# Altium schematic sheet MCU_and_conncectors.SchDoc(MCU_and_conncectors)
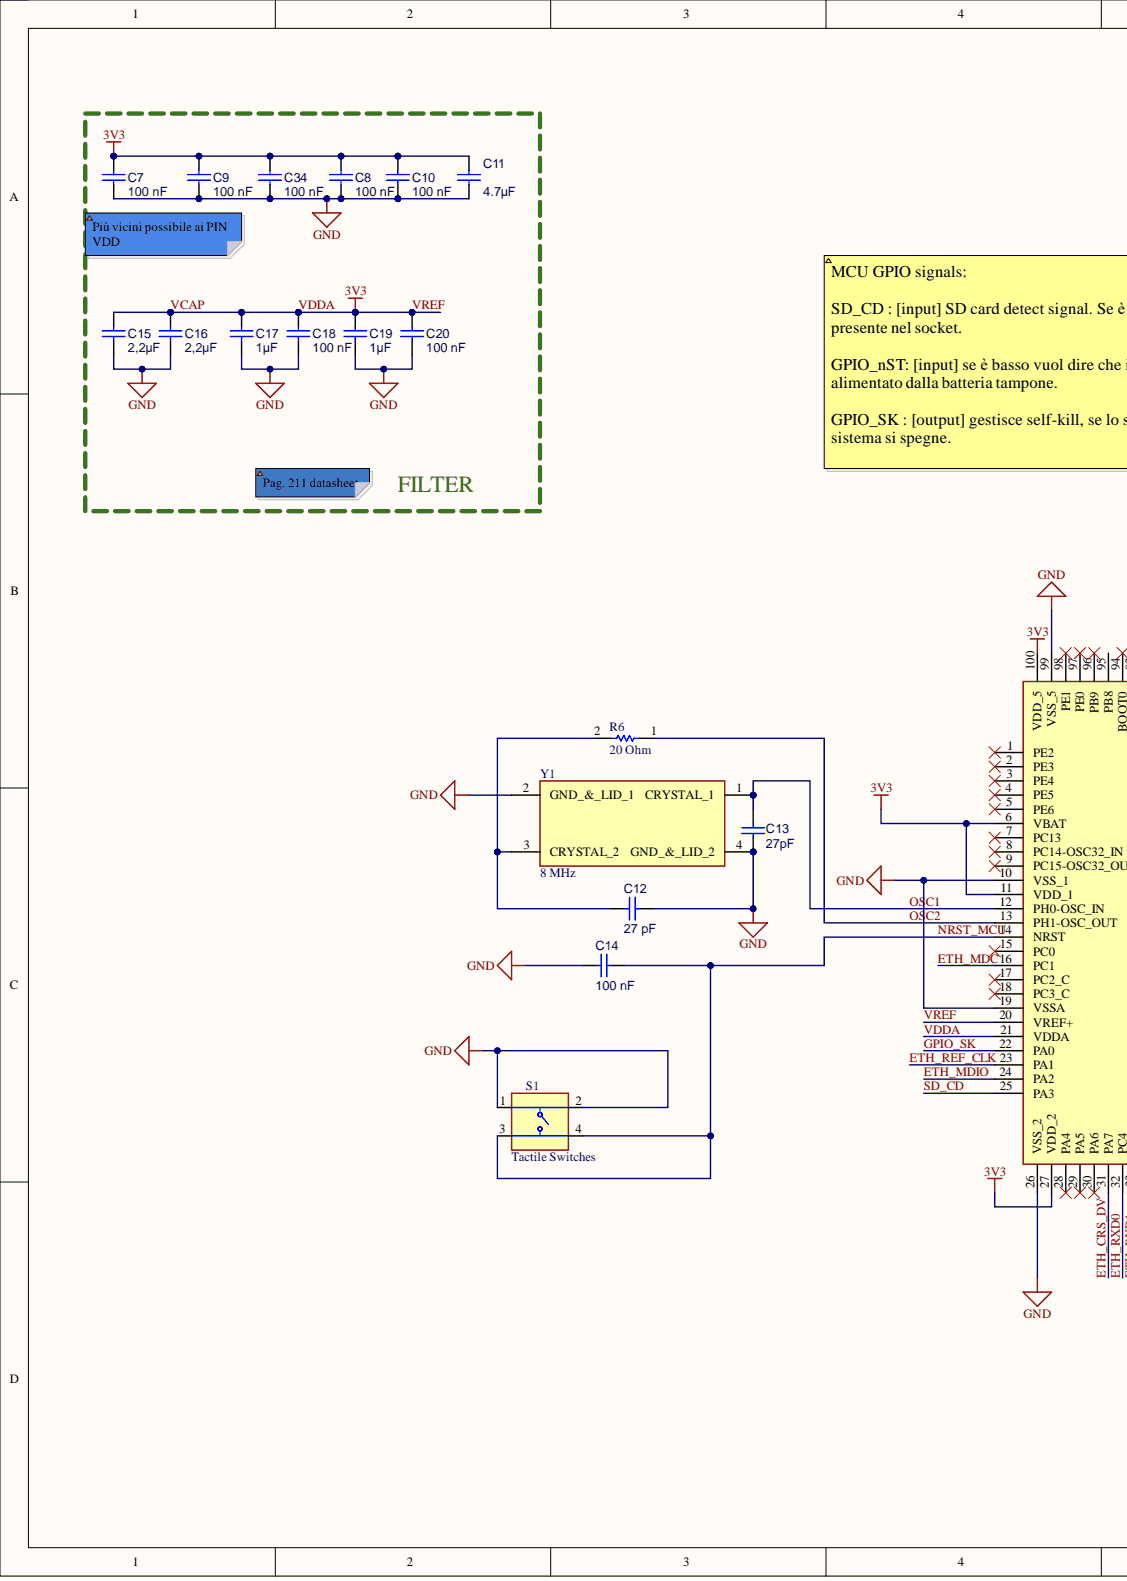
[diagram p0: MCU_and_conncectors.SchDoc, left]
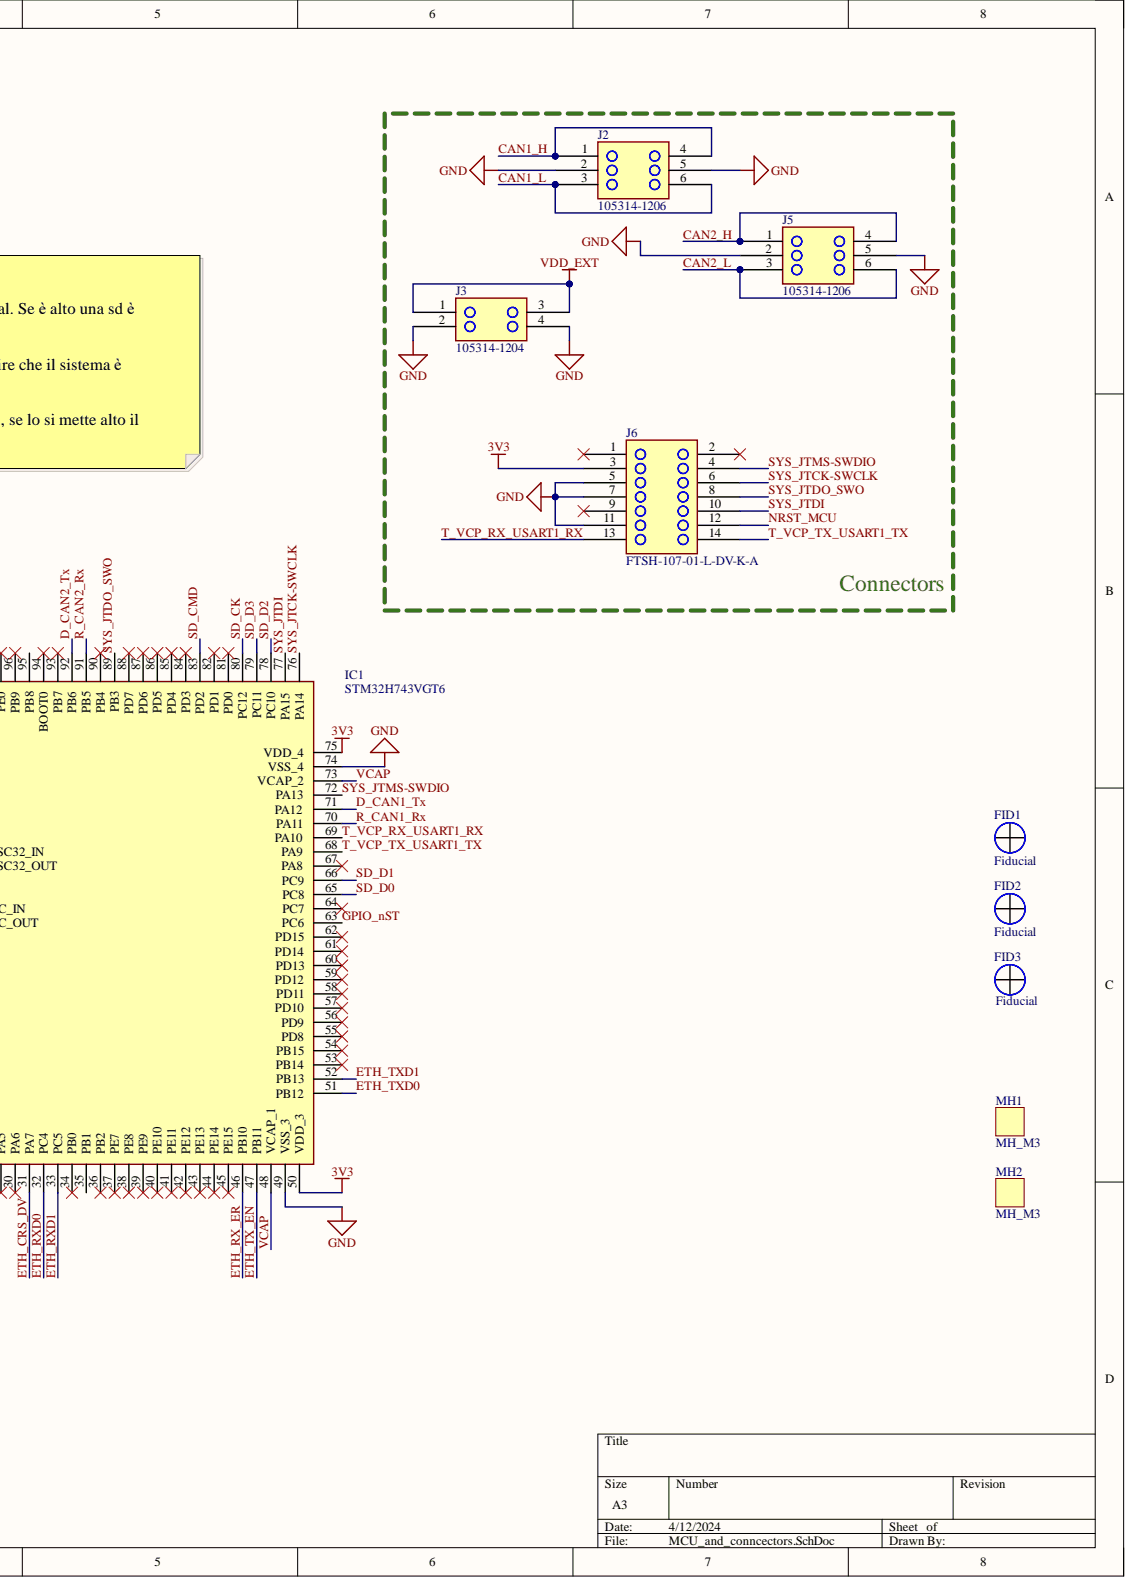
[diagram p1: MCU_and_conncectors.SchDoc, right]

## Parts
C7  100 nF
C8  100 nF
C9  100 nF
C10  100 nF
C11  4.7µF
C12  27 pF
C13  27pF
C14  100 nF
C15  2,2µF
C16  2,2µF
C17  1µF
C18  100 nF
C19  1µF
C20  100 nF
C34  100 nF
FID1  Fiducial
FID2  Fiducial
FID3  Fiducial
IC1  STM32H743VGT6
J2  105314-1206
J3  105314-1204
J5  105314-1206
J6  FTSH-107-01-L-DV-K-A
MH1  MH_M3
MH2  MH_M3
R6  20 Ohm
S1  Tactile Switches
Y1  8 MHz

## Nets
GND: C7.1 C8.1 C9.1 C10.1 C11.1 C12.1 C13.2 C14.2 C15.1 C16.1 C17.1 C18.1 C19.1 C20.1 C34.1 IC1.10(VSS_1) IC1.19(VSSA) IC1.26(VSS_2) IC1.49(VSS_3) IC1.74(VSS_4) IC1.99(VSS_5) J2.2 J2.5 J3.2 J3.4 J5.2 J5.5 J6.5 J6.7 J6.11 S1.1 S1.2 Y1.2(GND_&_LID_1) Y1.4(GND_&_LID_2)
VDD_EXT: J3.1 J3.3
CAN1_H: J2.1 J2.4
CAN1_L: J2.3 J2.6
CAN2_H: J5.1 J5.4
CAN2_L: J5.3 J5.6
D_CAN1_Tx: IC1.71(PA12)
D_CAN2_Tx: IC1.92(PB6)
ETH_CRS_DV: IC1.31(PA7)
ETH_MDC: IC1.16(PC1)
ETH_MDIO: IC1.24(PA2)
ETH_REF_CLK: IC1.23(PA1)
ETH_RX_ER: IC1.46(PB10)
ETH_RXD0: IC1.32(PC4)
ETH_RXD1: IC1.33(PC5)
ETH_TX_EN: IC1.47(PB11)
ETH_TXD0: IC1.51(PB12)
ETH_TXD1: IC1.52(PB13)
GPIO_nST: IC1.63(PC6)
GPIO_SK: IC1.22(PA0)
NRST_MCU: C14.1 IC1.14(NRST) J6.12 S1.3 S1.4
OSC1: C13.1 IC1.12(PH0-OSC_IN) Y1.1(CRYSTAL_1)
OSC2: IC1.13(PH1-OSC_OUT) R6.1
R_CAN1_Rx: IC1.70(PA11)
R_CAN2_Rx: IC1.91(PB5)
SD_CD: IC1.25(PA3)
SD_CK: IC1.80(PC12)
SD_CMD: IC1.83(PD2)
SD_D0: IC1.65(PC8)
SD_D1: IC1.66(PC9)
SD_D2: IC1.78(PC10)
SD_D3: IC1.79(PC11)
SYS_JTCK-SWCLK: IC1.76(PA14) J6.6
SYS_JTDI: IC1.77(PA15) J6.10
SYS_JTDO_SWO: IC1.89(PB3) J6.8
SYS_JTMS-SWDIO: IC1.72(PA13) J6.4
T_VCP_RX_USART1_RX: IC1.69(PA10) J6.13
T_VCP_TX_USART1_TX: IC1.68(PA9) J6.14
VCAP: C7.2 C8.2 C9.2 C10.2 C11.2 C15.2 C16.2 C17.2 C18.2 C19.2 C20.2 C34.2 IC1.6(VBAT) IC1.11(VDD_1) IC1.20(VREF+) IC1.21(VDDA) IC1.27(VDD_2) IC1.48(VCAP_1) IC1.50(VDD_3) IC1.73(VCAP_2) IC1.75(VDD_4) IC1.100(VDD_5) J6.3
NetC12_2: C12.2 R6.2 Y1.3(CRYSTAL_2)

Not connected: IC1 1(PE2) 2(PE3) 3(PE4) 4(PE5) 5(PE6) 7(PC13) 8(PC14-OSC32_IN) 9(PC15-OSC32_OUT) 15(PC0) 17(PC2_C) 18(PC3_C) 28(PA4) 29(PA5) 30(PA6) 34(PB0) 35(PB1) 36(PB2) 37(PE7) 38(PE8) 39(PE9) 40(PE10) 41(PE11) 42(PE12) 43(PE13) 44(PE14) 45(PE15) 53(PB14) 54(PB15) 55(PD8) 56(PD9) 57(PD10) 58(PD11) 59(PD12) 60(PD13) 61(PD14) 62(PD15) 64(PC7) 67(PA8) 81(PD0) 82(PD1) 84(PD3) 85(PD4) 86(PD5) 87(PD6) 88(PD7) 90(PB4) 93(PB7) 94(BOOT0) 95(PB8) 96(PB9) 97(PE0) 98(PE1); J6 1 2 9

## Library data of the parts
C7-C10 C14 C18 C20 C34 = CMP-001-00085-5: VJ0805Y104MXATW1BC; Tech: SMD; Component Kind: Standard; ComponentLink1Description: Website; Package: 0805; Library Name: EMEA- Squadra Corse PoliTO-  Politecnico di Torino
C11 = CMP-001-00085-5: VJ0805G475KXQTW1BC; Tech: SMD; Component Kind: Standard; ComponentLink1Description: Website; Package: 0805; Library Name: EMEA- Squadra Corse PoliTO-  Politecnico di Torino
C12 C13 = CMP-001-00085-5: VJ0805A270FXXPW1BC; Tech: SMD; Component Kind: Standard; ComponentLink1Description: Website; Package: 0805; Library Name: EMEA- Squadra Corse PoliTO-  Politecnico di Torino
C15 C16 = CMP-001-00085-5: VJ0805Y225KXJTW1BC; Tech: SMD; Component Kind: Standard; ComponentLink1Description: Website; Package: 0805; Library Name: EMEA- Squadra Corse PoliTO-  Politecnico di Torino
C17 C19 = CMP-001-00085-5: VJ0805Y105KXXTW1BC; Tech: SMD; Component Kind: Standard; ComponentLink1Description: Website; Package: 0805; Library Name: EMEA- Squadra Corse PoliTO-  Politecnico di Torino
FID1-FID3 = CMP-00028-00007-10: Component Kind: Standard; Library Name: EMEA- Squadra Corse PoliTO-  Politecnico di Torino
IC1 = CMP-030-000044-1: Microcontrollori ARM - MCU High-performance & DSP DP-FPU, Arm Cortex-M7 MCU 1MBytes of Flash 1MB RAM, 480 M; Manufacturer_Name: STMicroelectronics; Arrow Part Number: STM32H743VGT6; Arrow Price/Stock: https://www.arrow.com/en/products/stm32h743vgt6/stmicroelectronics?region=nac; Component Kind: Standard; Datasheet Link: https://componentsearchengine.com/Datasheets/1/STM32H743VGT6.pdf; Design Item ID: STM32H743VGT6; Height: 1.6mm; Manufacturer_Part_Number: STM32H743VGT6; Mouser Part Number: 511-STM32H743VGT6; Mouser Price/Stock: https://www.mouser.co.uk/ProductDetail/STMicroelectronics/STM32H743VGT6?qs=%252B6g0mu59x7JjCCaXtUod8g%3D%3D; Source: SamacSys.SchLib; Library Name: EMEA- Squadra Corse PoliTO-  Politecnico di Torino
J2 J5 = CMP-000003-4: NanoFit 6 Pin, Right Angle, Gold Plating; Component Kind: Standard; Library Name: EMEA- Squadra Corse PoliTO-  Politecnico di Torino
J3 = CMP-000004-3: Nano-fit, Right angle, Gold Plated; Component Kind: Standard; Library Name: EMEA- Squadra Corse PoliTO-  Politecnico di Torino
J6 = CMP-00004-4: Samtec Header, 14 Pin, 1.27mm pitch; Component Kind: Standard; Library Name: EMEA- Squadra Corse PoliTO-  Politecnico di Torino
MH1 MH2 = CMP-00028-00008-3: Mountinh Hole M3, 3,5 mm hole, 6,5 mm external; Component Kind: Standard; Library Name: EMEA- Squadra Corse PoliTO-  Politecnico di Torino
R6 = CMP-009-00179-5: CRCW060320R0FKEAC; Tech: SMD; Component Kind: Standard; ComponentLink1Description: Website; Package: 0603; Library Name: EMEA- Squadra Corse PoliTO-  Politecnico di Torino
S1 = CMP-011-000004-5: RKC2SJF170SMTR_LFS; Manufacturer_Name: C & K COMPONENTS; Manufacturer_Part_Number: RKC2SJF170SMTR LFS; Arrow Part Number: RKC2SJF170SMTR LFS; Arrow Price/Stock: https://www.arrow.com/en/products/rkc2sjf170smtr-lfs/ck?region=nac; Component Kind: Standard; Datasheet Link: https://www.ckswitches.com/media/3470/ck_rkc2_datasheet.pdf; Design Item ID: RKC2SJF170SMTR_LFS; Height: 1.9mm; Mouser Part Number: 611-RKC2SJF170SMRLFS; Mouser Price/Stock: https://www.mouser.co.uk/ProductDetail/CK/RKC2SJF170SMTR-LFS?qs=XAiT9M5g4x%252BRRI9derenng%3D%3D; Source: SamacSys.SchLib; Library Name: EMEA- Squadra Corse PoliTO-  Politecnico di Torino
Y1 = CMP-004-000011-4: 830055663; Manufacturer_Name: Wurth Elektronik; Component Kind: Standard; Datasheet Link: https://www.we-online.com/catalog/datasheet/830055663.pdf; Design Item ID: 830055663; Height: 1mm; Manufacturer_Part_Number: 830055663; Mouser Part Number: 710-830055663; Mouser Price/Stock: https://www.mouser.co.uk/ProductDetail/Wurth-Elektronik/830055663?qs=2WXlatMagcHXGqDkS%2FTHKQ%3D%3D; Source: SamacSys.SchLib; Library Name: EMEA- Squadra Corse PoliTO-  Politecnico di Torino
